# Altium schematic sheet Power.SchDoc(Power)
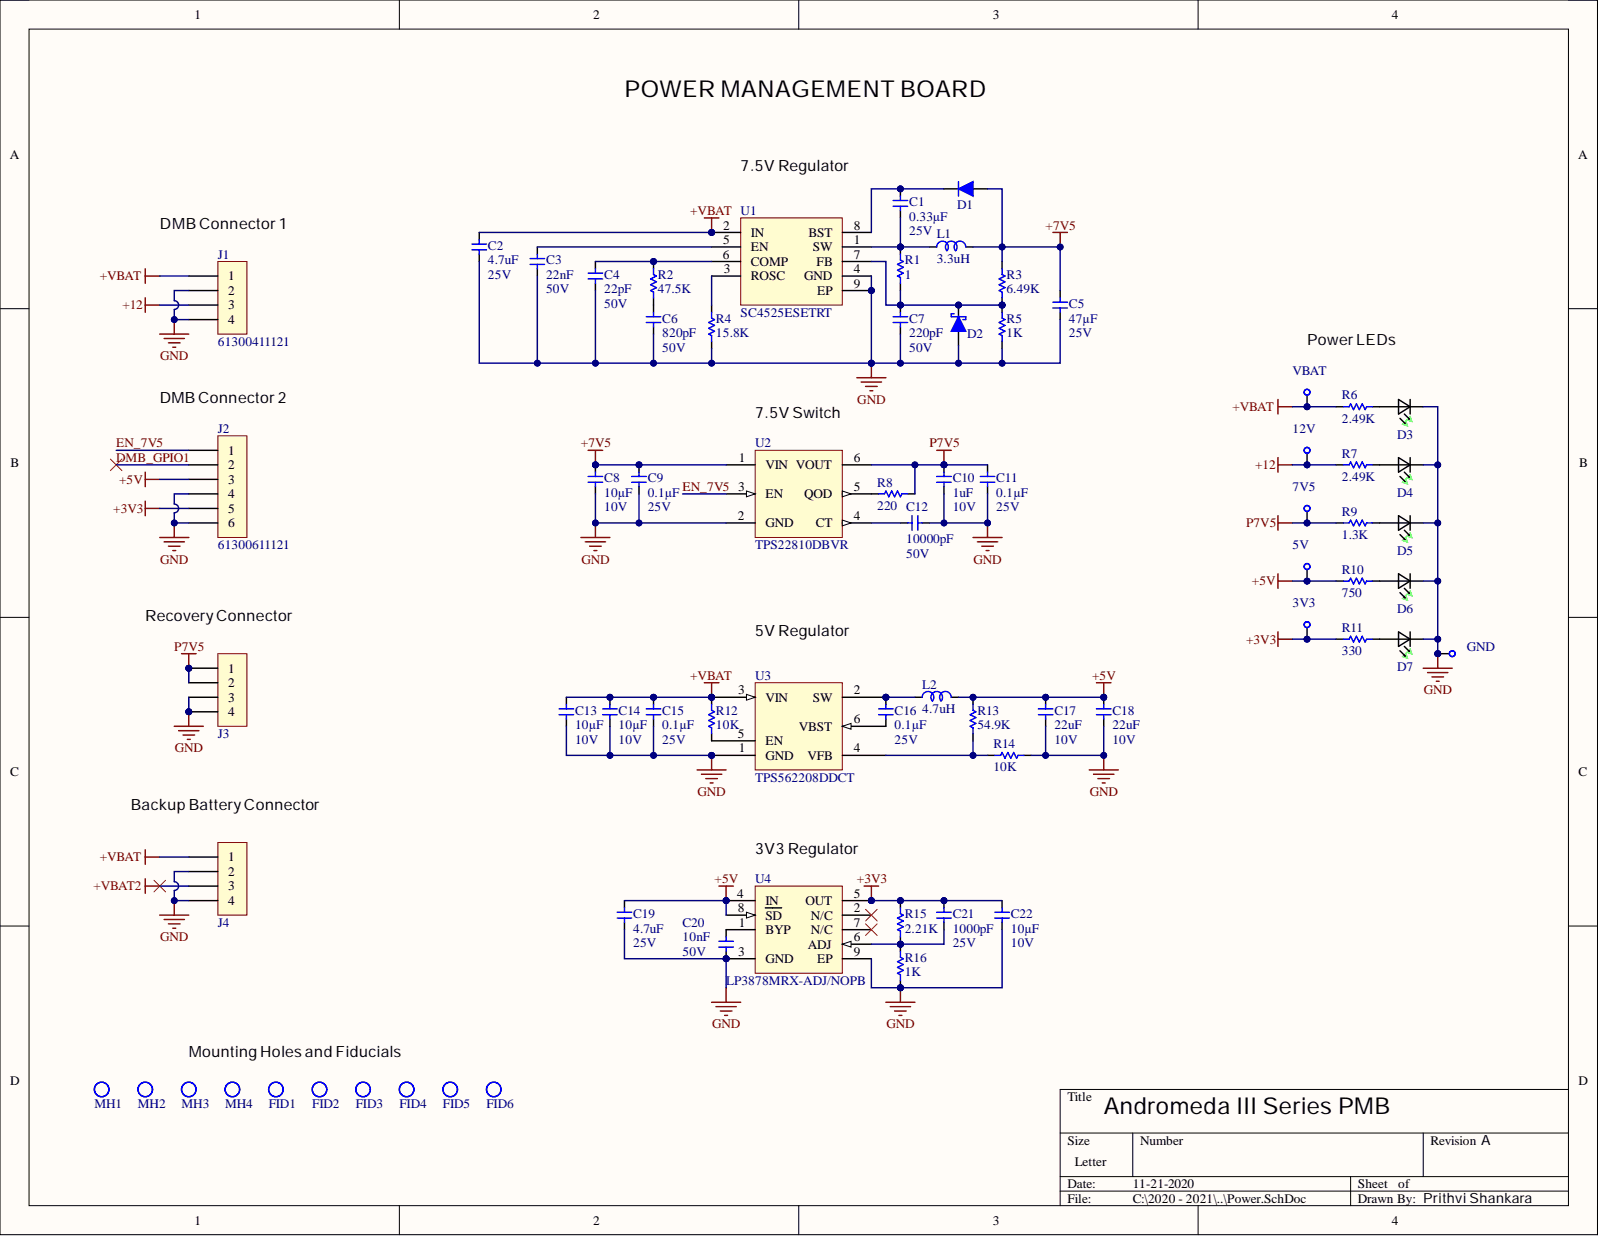
[diagram p0: Power.SchDoc]

## Parts
3V3  Testpoint  [Testpoint]  (value not drawn)  (no BOM)
5V  Testpoint  [Testpoint]  (value not drawn)  (no BOM)
7V5  Testpoint  [Testpoint]  (value not drawn)  (no BOM)
12V  Testpoint  [Testpoint]  (value not drawn)  (no BOM)
C1  Capacitance: 0.33µF  Voltage - Rated: 25V  [0603[1608]]  (hidden comment: CGA3E3X7R1E334K080AB)
C2  Capacitance: 4.7uF  Voltage - Rated: 25V  [0603[1608]]  (hidden comment: CL10A475KA8NQNC)
C3  Capacitance: 22nF  Voltage - Rated: 50V  [0603[1608]]  (hidden comment: CC0603KRX7R9BB223)
C4  Capacitance: 22pF  Voltage - Rated: 50V  [0603[1608]]  (hidden comment: CC0603JRNPO9BN220)
C5  Capacitance: 47µF  Voltage - Rated: 25V  [1206[3216]]  (hidden comment: C3216X5R1E476M160AC)
C6  Capacitance: 820pF  Voltage - Rated: 50V  [0603[1608]]  (hidden comment: CC0603KRX7R9BB821)
C7  Capacitance: 220pF  Voltage - Rated: 50V  [0603[1608]]  (hidden comment: CC0603KRX7R9BB221)
C8  Capacitance: 10µF  Voltage - Rated: 10V  [0603[1608]]  (hidden comment: CL10A106MP8NNNC)
C9  Capacitance: 0.1µF  Voltage - Rated: 25V  [0603[1608]]  (hidden comment: CGA3E2X7R1E104K080AA)
C10  Capacitance: 1uF  Voltage - Rated: 10V  [0603[1608]]  (hidden comment: CL10A105KP8NNNC)
C11  Capacitance: 0.1µF  Voltage - Rated: 25V  [0603[1608]]  (hidden comment: CGA3E2X7R1E104K080AA)
C12  Capacitance: 10000pF  Voltage - Rated: 50V  [0603[1608]]  (hidden comment: CGA3E2X7R1H103K080AA)
C13  Capacitance: 10µF  Voltage - Rated: 10V  [0603[1608]]  (hidden comment: CL10A106MP8NNNC)
C14  Capacitance: 10µF  Voltage - Rated: 10V  [0603[1608]]  (hidden comment: CL10A106MP8NNNC)
C15  Capacitance: 0.1µF  Voltage - Rated: 25V  [0603[1608]]  (hidden comment: CGA3E2X7R1E104K080AA)
C16  Capacitance: 0.1µF  Voltage - Rated: 25V  [0603[1608]]  (hidden comment: CGA3E2X7R1E104K080AA)
C17  Capacitance: 22uF  Voltage - Rated: 10V  [0603[1608]]  (hidden comment: C1608X5R1A226M080AC)
C18  Capacitance: 22uF  Voltage - Rated: 10V  [0603[1608]]  (hidden comment: C1608X5R1A226M080AC)
C19  Capacitance: 4.7uF  Voltage - Rated: 25V  [0603[1608]]  (hidden comment: CL10A475KA8NQNC)
C20  Capacitance: 10nF  Voltage - Rated: 50V  [0603[1608]]  (hidden comment: GRM1885C1H103JA01D)
C21  Capacitance: 1000pF  Voltage - Rated: 25V  [0603[1608]]  (hidden comment: C0603C102K3GACTU)
C22  Capacitance: 10µF  Voltage - Rated: 10V  [0603[1608]]  (hidden comment: CL10A106MP8NNNC)
D1  1N4148W-7-F  [1N4148W-7-F]  (value not drawn)
D2  B330A-13-F  [B330A-13-F]  (value not drawn)
D3  LTST-C191KGKT  [LTST-C191KGKT]  (value not drawn)
D4  LTST-C191KGKT  [LTST-C191KGKT]  (value not drawn)
D5  LTST-C191KGKT  [LTST-C191KGKT]  (value not drawn)
D6  LTST-C191KGKT  [LTST-C191KGKT]  (value not drawn)
D7  LTST-C191KGKT  [LTST-C191KGKT]  (value not drawn)
FID1  FID_1MM_ROUND_SL  [FID_1MM_ROUND_SL]  (value not drawn)  (no BOM)
FID2  FID_1MM_ROUND_SL  [FID_1MM_ROUND_SL]  (value not drawn)  (no BOM)
FID3  FID_1MM_ROUND_SL  [FID_1MM_ROUND_SL]  (value not drawn)  (no BOM)
FID4  FID_1MM_ROUND_SL  [FID_1MM_ROUND_SL]  (value not drawn)  (no BOM)
FID5  FID_1MM_ROUND_SL  [FID_1MM_ROUND_SL]  (value not drawn)  (no BOM)
FID6  FID_1MM_ROUND_SL  [FID_1MM_ROUND_SL]  (value not drawn)  (no BOM)
GND  Testpoint  [Testpoint]  (value not drawn)  (no BOM)
J1  61300411121  [61300411121]
J2  61300611121  [61300611121]
J3  0430450400  [0430450400]  (value not drawn)
J4  0430450400  [0430450400]  (value not drawn)
L1  value 3.3uH  [744777003]  (hidden comment: 744777003)
L2  value 4.7uH  [DFE252012P-4R7M=P2]  (hidden comment: DFE252012P-4R7M=P2)
MH1  9774110360R  [Standoff]  (value not drawn)
MH2  9774110360R  [Standoff]  (value not drawn)
MH3  Mounting Hole  [MOUNT-HOLE]  (no BOM)
MH4  Mounting Hole  [MOUNT-HOLE]  (no BOM)
R1  RC0603FR-071RL  [0603[1608]]  (value not drawn)
R2  Resistance: 47.5K  [0603[1608]]  (hidden comment: ERA-3AEB4752V)
R3  Resistance: 6.49K  [0603[1608]]  (hidden comment: RT0603DRE076K49L)
R4  Resistance: 15.8K  [0603[1608]]  (hidden comment: ERA-3AEB1582V)
R5  Resistance: 1K  [0603[1608]]  (hidden comment: RC0603FR-071KL)
R6  Resistance: 2.49K  [0603[1608]]  (hidden comment: ERA-3AEB2491V)
R7  Resistance: 2.49K  [0603[1608]]  (hidden comment: ERA-3AEB2491V)
R8  CRGCQ0603F220R  [0603[1608]]  (value not drawn)
R9  Resistance: 1.3K  [0603[1608]]  (hidden comment: MCT06030C1301FP500)
R10  ESR03EZPJ751  [0603[1608]]  (value not drawn)
R11  RC1608F331CS  [0603[1608]]  (value not drawn)
R12  Resistance: 10K  [0603[1608]]  (hidden comment: ERJ-3GEYJ103V)
R13  Resistance: 54.9K  [0603[1608]]  (hidden comment: RC0603FR-0754K9L)
R14  Resistance: 10K  [0603[1608]]  (hidden comment: ERJ-3GEYJ103V)
R15  Resistance: 2.21K  [0603[1608]]  (hidden comment: ERJ-3EKF2211V)
R16  Resistance: 1K  [0603[1608]]  (hidden comment: RC0603FR-071KL)
U1  SC4525ESETRT  [SOIC127P600X175_HS-9N]
U2  TPS22810DBVR  [SOT95P280X145-6N]
U3  TPS562208DDCT  [TPS562208DDCT]
U4  LP3878MRX-ADJ/NOPB  [SOIC127P600X170_HS-9N]
VBAT  Testpoint  [Testpoint]  (value not drawn)  (no BOM)

## Nets
+3V3: 3V3.1 C21.2 C22.2 J2.5 R11.1 R15.2 U4.5(OUT)
+5V: 5V.1 C17.2 C18.2 C19.2 J2.3 L2.2 R10.1 R13.1 U4.4(IN) U4.8(SD)
+7V5: C5.2 C8.2 C9.2 D1.1 L1.2 R3.2 U2.1(VIN)
+12: 12V.1 J1.3 R7.1
+VBAT: C2.2 C13.2 C14.2 C15.2 J1.1 J4.1 R6.1 R12.2 U1.2(IN) U3.3(VIN) VBAT.1
+VBAT2: J4.3
GND: C2.1 C3.1 C4.1 C5.1 C6.1 C7.1 C8.1 C9.1 C10.1 C11.1 C12.2 C13.1 C14.1 C15.1 C17.1 C18.1 C19.1 C20.1 C22.1 D2.1 D3.1 D4.1 D5.1 D6.1 D7.1 GND.1 J1.2 J1.4 J2.4 J2.6 J3.3 J3.4 J4.2 J4.4 R4.1 R5.1 R14.1 R16.1 U1.4(GND) U1.9(EP) U2.2(GND) U3.1(GND) U4.3(GND) U4.9(EP)
P7V5: 7V5.1 C10.2 C11.2 J3.1 J3.2 R8.2 R9.1 U2.6(VOUT)
DMB_GPIO1: J2.2
EN_7V5: J2.1 U2.3(EN)
NetC1_1: C1.1 L1.1 R1.2 U1.1(SW)
NetC1_2: C1.2 D1.2 U1.8(BST)
NetC3_2: C3.2 U1.5(EN)
NetC4_2: C4.2 R2.2 U1.6(COMP)
NetC6_2: C6.2 R2.1
NetC7_2: C7.2 D2.2 R1.1 R3.1 R5.2 U1.7(FB)
NetC12_1: C12.1 U2.4(CT)
NetC16_1: C16.1 U3.6(VBST)
NetC16_2: C16.2 L2.1 U3.2(SW)
NetC20_2: C20.2 U4.1(BYP)
NetC21_1: C21.1 R15.1 R16.2 U4.6(ADJ)
NetD3_2: D3.2 R6.2
NetD4_2: D4.2 R7.2
NetD5_2: D5.2 R9.2
NetD6_2: D6.2 R10.2
NetD7_2: D7.2 R11.2
NetR4_2: R4.2 U1.3(ROSC)
NetR8_1: R8.1 U2.5(QOD)
NetR12_1: R12.1 U3.5(EN)
NetR13_2: R13.2 R14.2 U3.4(VFB)

Not connected: U4 2(N/C) 7(N/C)

## Library data of the parts
3V3 5V 7V5 12V GND VBAT = Testpoint: TESTPOINT 0.7MMD Hole, 1.2MMW, 1.2MML; Library Name: SOARSchematics.SchLib
C1 = CGA3E3X7R1E334K080AB: CAP CER 0.33UF 25V X7R 0603; Manufacturer: TDK Corporation; Tolerance: ±10%; Component Kind: Standard; ComponentLink1Description: Datasheet; ComponentLink1URL: https://content.kemet.com/datasheets/KEM_C1003_C0G_SMD.pdf; Manufacturer Part Number: CGA3E3X7R1E334K080AB; Mounting Type: Surface Mount, MLCC; Operating Temperature: -55°C ~ 125°C; Package / Case: 0603 (1608 Metric); Size / Dimension: 0.063" L x 0.031" W (1.60mm x 0.80mm); Supplier 1: Digi-Key; Supplier Part Number 1: 445-12544-1-ND; Temperature Coefficient: X7R; Thickness (Max): 0.034" (0.87mm); Library Name: SOARSchematics.SchLib
C2 C19 = CL10A475KA8NQNC: CAP CER 4.7UF 25V X5R 0603; Manufacturer: Samsung Electro-Mechanics; Tolerance: ±10%; Component Kind: Standard; ComponentLink1Description: Datasheet; ComponentLink1URL: http://www.samsungsem.com/kr/support/product-search/mlcc/__icsFiles/afieldfile/2019/05/10/19-CL10A475KA8NQNC.pdf; Manufacturer Part Number: CL10A475KA8NQNC; Mounting Type: Surface Mount, MLCC; Operating Temperature: -55°C ~ 85°C; Package / Case: 0603 (1608 Metric); Size / Dimension: 0.063" L x 0.031" W (1.60mm x 0.80mm); Supplier 1: Digi-Key; Supplier Part Number 1: 1276-1900-1-ND; Temperature Coefficient: X5R; Thickness (Max): 0.035" (0.90mm); Library Name: SOARSchematics.SchLib
C3 = CC0603KRX7R9BB223: CAP CER 0.022UF 50V X7R 0603; Manufacturer: Yageo; Tolerance: ±10%; Component Kind: Standard; ComponentLink1Description: Datasheet; ComponentLink1URL: https://www.yageo.com/upload/media/product/productsearch/datasheet/mlcc/UPY-GP_NP0_16V-to-50V_18.pdf; Manufacturer Part Number: CC0603KRX7R9BB223; Mounting Type: Surface Mount, MLCC; Operating Temperature: -55°C ~ 125°C; Package / Case: 0603 (1608 Metric); Size / Dimension: 0.063" L x 0.031" W (1.60mm x 0.80mm); Supplier 1: Digi-Key; Supplier Part Number 1: 311-1370-1-ND; Temperature Coefficient: X7R; Thickness (Max): 0.035" (0.90mm); Library Name: SOARSchematics.SchLib
C4 = CC0603JRNPO9BN220: CAP CER 22PF 50V C0G/NPO 0603; Manufacturer: Yageo; Temperature Coefficient: C0G, NP0; Tolerance: ±5%; Component Kind: Standard; ComponentLink1Description: Datasheet; ComponentLink1URL: https://www.yageo.com/upload/media/product/productsearch/datasheet/mlcc/UPY-GP_NP0_16V-to-50V_18.pdf; Manufacturer Part Number: CC0603JRNPO9BN220; Mounting Type: Surface Mount, MLCC; Operating Temperature: -55°C ~ 125°C; Package / Case: 0603 (1608 Metric); Size / Dimension: 0.063" L x 0.031" W (1.60mm x 0.80mm); Supplier 1: Digi-Key; Supplier Part Number 1: 311-1062-1-ND; Thickness (Max): 0.035" (0.90mm); Library Name: SOARSchematics.SchLib
C5 = C3216X5R1E476M160AC: CAP CER 47UF 25V X5R 1206; Manufacturer: TDK Corporation; Tolerance: ±20%; Component Kind: Standard; ComponentLink1Description: Datasheet; ComponentLink1URL: http://www.samsungsem.com/kr/support/product-search/mlcc/__icsFiles/afieldfile/2019/05/10/19-CL10A105KP8NNNC.pdf; Manufacturer Part Number: C3216X5R1E476M160AC; Mounting Type: Surface Mount, MLCC; Operating Temperature: -55°C ~ 85°C; Package / Case: 1206 (3216 Metric); Size / Dimension: 0.126" L x 0.063" W (3.20mm x 1.60mm); Supplier 1: Digi-Key; Supplier Part Number 1: 445-8047-1-ND; Temperature Coefficient: X5R; Thickness (Max): 0.071" (1.80mm); Library Name: SOARSchematics.SchLib
C6 = CC0603KRX7R9BB821: CAP CER 820PF 50V X7R 0603; Manufacturer: Yageo; Tolerance: ±10%; Component Kind: Standard; ComponentLink1Description: Datasheet; ComponentLink1URL: https://www.yageo.com/upload/media/product/productsearch/datasheet/mlcc/UPY-GP_NP0_16V-to-50V_18.pdf; Manufacturer Part Number: CC0603KRX7R9BB821; Mounting Type: Surface Mount, MLCC; Operating Temperature: -55°C ~ 125°C; Package / Case: 0603 (1608 Metric); Size / Dimension: 0.063" L x 0.031" W (1.60mm x 0.80mm); Supplier 1: Digi-Key; Supplier Part Number 1: 311-1189-1-ND; Temperature Coefficient: X7R; Thickness (Max): 0.035" (0.90mm); Library Name: SOARSchematics.SchLib
C7 = CC0603KRX7R9BB221: CAP CER 220PF 50V X7R 0603; Manufacturer: Yageo; Tolerance: ±10%; Component Kind: Standard; ComponentLink1Description: Datasheet; ComponentLink1URL: https://www.yageo.com/upload/media/product/productsearch/datasheet/mlcc/UPY-GP_NP0_16V-to-50V_18.pdf; Manufacturer Part Number: CC0603KRX7R9BB221; Mounting Type: Surface Mount, MLCC; Operating Temperature: -55°C ~ 125°C; Package / Case: 0603 (1608 Metric); Size / Dimension: 0.063" L x 0.031" W (1.60mm x 0.80mm); Supplier 1: Digi-Key; Supplier Part Number 1: 311-1077-1-ND; Temperature Coefficient: X7R; Thickness (Max): 0.035" (0.90mm); Library Name: SOARSchematics.SchLib
C8 C13 C14 C22 = CL10A106MP8NNNC: CAP CER 10UF 10V X5R 0603; Manufacturer: Samsung Electro-Mechanics; Tolerance: ±20%; Component Kind: Standard; ComponentLink1Description: Datasheet; ComponentLink1URL: https://static6.arrow.com/aropdfconversion/f91a1722e2705c3d73cfb1979021b64ae4055093/19-cl10a106mp8nnnc.pdf; Manufacturer Part Number: CL10A106MP8NNNC; Mounting Type: Surface Mount, MLCC; Operating Temperature: -55°C ~ 85°C; Package / Case: 0603 (1608 Metric); Size / Dimension: 0.063" L x 0.031" W (1.60mm x 0.80mm); Supplier 1: Digi-Key; Supplier Part Number 1: 1276-1871-1-ND; Temperature Coefficient: X5R; Thickness (Max): 0.035" (0.90mm); Library Name: SOARSchematics.SchLib
C9 C11 C15 C16 = CGA3E2X7R1E104K080AA: CAP CER 0.1UF 25V X7R 0603; Manufacturer: TDK Corporation; Tolerance: ±10%; Component Kind: Standard; ComponentLink1Description: Datasheet; ComponentLink1URL: https://product.tdk.com/info/en/catalog/spec/mlccspec_automotive_general_en.pdf; Manufacturer Part Number: CGA3E2X7R1E104K080AA; Mounting Type: Surface Mount, MLCC; Operating Temperature: -55°C ~ 125°C; Package / Case: 0603 (1608 Metric); Size / Dimension: 0.063" L x 0.031" W (1.60mm x 0.80mm); Supplier 1: Digi-Key; Supplier Part Number 1: 445-5667-1-ND; Temperature Coefficient: X7R; Thickness (Max): 0.035" (0.90mm); Library Name: SOARSchematics.SchLib
C10 = CL10A105KP8NNNC: CAP CER 1UF 10V X5R 0603; Manufacturer: Samsung Electro-Mechanics; Tolerance: ±10%; Component Kind: Standard; ComponentLink1Description: Datasheet; ComponentLink1URL: http://www.samsungsem.com/kr/support/product-search/mlcc/__icsFiles/afieldfile/2019/05/10/19-CL10A105KP8NNNC.pdf; Manufacturer Part Number: CL10A105KP8NNNC; Mounting Type: Surface Mount, MLCC; Operating Temperature: -55°C ~ 85°C; Package / Case: 0603 (1608 Metric); Size / Dimension: 0.063" L x 0.031" W (1.60mm x 0.80mm); Supplier 1: Digi-Key; Supplier Part Number 1: 1276-1182-1-ND; Temperature Coefficient: X5R; Thickness (Max): 0.035" (0.90mm); Library Name: SOARSchematics.SchLib
C12 = CGA3E2X7R1H103K080AA: CAP CER 10000PF 50V X7R 0603; Manufacturer: TDK Corporation; Tolerance: ±10%; Component Kind: Standard; ComponentLink1Description: Datasheet; ComponentLink1URL: https://product.tdk.com/info/en/catalog/spec/mlccspec_automotive_general_en.pdf; Manufacturer Part Number: CGA3E2X7R1H103K080AA; Mounting Type: Surface Mount, MLCC; Operating Temperature: -55°C ~ 125°C; Package / Case: 0603 (1608 Metric); Size / Dimension: 0.063" L x 0.031" W (1.60mm x 0.80mm); Supplier 1: Digi-Key; Supplier Part Number 1: 445-5662-1-ND; Temperature Coefficient: X7R; Thickness (Max): 0.035" (0.90mm); Library Name: SOARSchematics.SchLib
C17 C18 = C1608X5R1A226M080AC: CAP CER 22UF 10V X5R 0603; Manufacturer: TDK Corporation; Tolerance: ±20%; Component Kind: Standard; ComponentLink1Description: Datasheet; ComponentLink1URL: http://datasheets.avx.com/C0GNP0-Dielectric.pdf; Manufacturer Part Number: C1608X5R1A226M080AC; Mounting Type: Surface Mount, MLCC; Operating Temperature: -55°C ~ 85°C; Package / Case: 0603 (1608 Metric); Size / Dimension: 0.063" L x 0.031" W (1.60mm x 0.80mm); Supplier 1: Digi-Key; Supplier Part Number 1: 445-9077-1-ND; Temperature Coefficient: X5R; Thickness (Max): 0.039" (1.00mm); Library Name: SOARSchematics.SchLib
C20 = GRM1885C1H103JA01D: CAP CER 10000PF 50V C0G/NP0 0603; Manufacturer: Murata Electronics; Temperature Coefficient: C0G, NP0; Tolerance: ±50%; Component Kind: Standard; ComponentLink1Description: Datasheet; ComponentLink1URL: https://www.murata.com/~/media/webrenewal/support/library/catalog/products/capacitor/mlcc/c02e.ashx?la=en-us; Manufacturer Part Number: GRM1885C1H103JA01D; Mounting Type: Surface Mount, MLCC; Operating Temperature: -55°C ~ 125°C; Package / Case: 0603 (1608 Metric); Size / Dimension: 0.063" L x 0.031" W (1.60mm x 0.80mm); Supplier 1: Digi-Key; Supplier Part Number 1: 490-9666-1-ND; Thickness (Max): 0.035" (0.90mm); Library Name: SOARSchematics.SchLib
C21 = C0603C102K3GACTU: CAP CER 1000PF 25V C0G/NP0 0603; Manufacturer: KEMET; Temperature Coefficient: C0G, NP0; Tolerance: ±10%; Component Kind: Standard; ComponentLink1Description: Datasheet; ComponentLink1URL: https://content.kemet.com/datasheets/KEM_C1003_C0G_SMD.pdf; Manufacturer Part Number: C0603C102K3GACTU; Mounting Type: Surface Mount, MLCC; Operating Temperature: -55°C ~ 85°C; Package / Case: 0603 (1608 Metric); Size / Dimension: 0.063" L x 0.031" W (1.60mm x 0.80mm); Supplier 1: Digi-Key; Supplier Part Number 1: 399-7833-1-ND; Thickness (Max): 0.034" (0.87mm); Library Name: SOARSchematics.SchLib
D1 = 1N4148W-7-F: DIODE GEN PURP 100V 300MA SOD123; Manufacturer: Diodes Incorporated; Capacitance @ Vr, F: 2pF @ 0V, 1MHz; Current - Average Rectified (Io): 300mA (DC); Current - Reverse Leakage @ Vr: 1µA @ 75V; Diode Type: Standard; Reverse Recovery Time (trr): 4ns; Speed: Small Signal =< 200mA (Io), Any Speed; Voltage - Forward (Vf) (Max) @ If: 1.25V @ 150mA; Component Kind: Standard; ComponentLink1Description: Datasheet; ComponentLink1URL: https://www.diodes.com/assets/Datasheets/ds30086.pdf; Manufacturer Part Number: 1N4148W-7-F; Mounting Type: Surface Mount; Operating Temperature - Junction: -65°C ~ 150°C; Package / Case: SOD-123; Supplier 1: Digi-Key; Supplier Part Number 1: 1N4148W-FDICT-ND; Voltage - DC Reverse (Vr) (Max): 100V; Library Name: SOARSchematics.SchLib
D2 = B330A-13-F: DIODE SCHOTTKY 30V 3A SMA; Manufacturer: Diodes Incorporated; 500mV @ 3A: Fast Recovery =< 500ns, > 200mA (Io); Capacitance @ Vr, F: 200pF @ 4V, 1MHz; Current - Reverse Leakage @ Vr: 500µA @ 30V; Voltage - Forward (Vf) (Max) @ If: 500mV @ 3A; Component Kind: Standard; ComponentLink1Description: Datasheet; ComponentLink1URL: https://www.diodes.com/assets/Datasheets/ds30891.pdf; Current - Average Rectified (Io): 3A; Manufacturer Part Number: B330A-13-F; Mounting Type: Surface Mount; Operating Temperature - Junction: -55°C ~ 150°C; Package / Case: DO-214AC, SMA; Supplier 1: Digi-Key; Supplier Part Number 1: B330A-FDICT-ND; Type: Schottky; Voltage - DC Reverse (Vr) (Max): 30V; Library Name: SOARSchematics.SchLib
D3-D7 = LTST-C191KGKT: LED GREEN CLEAR SMD 0603; Manufacturer: Lite-On Inc.; Current - Test: 20mA; Lens Color: Colourless; Lens Size: 1.10mm x 0.80mm; Lens Style: Rectangle with Flat Top; Millicandela Rating: 35mcd; Viewing Angle: 130°; Voltage - Forward (Vf) (Typ): 2V; Wavelength - Dominant: 571nm; Wavelength - Peak: 574nm; Colour: Green; Component Kind: Standard; ComponentLink1Description: Datasheet; ComponentLink1URL: http://optoelectronics.liteon.com/upload/download/DS22-2000-228/LTST-C191KGKT.PDF; Height (Max): 0.65mm; Lens Transparency: Clear; Manufacturer Part Number: LTST-C191KGKT; Mounting Type: Surface Mount; Package / Case: 0603 (1608 Metric); Size / Dimension: 1.60mm L x 0.80mm W; Supplier 1: Digi-Key; Supplier Part Number 1: 160-1446-1-ND; Library Name: SOARSchematics.SchLib
FID1-FID6 = FID_1MM_ROUND_SL: Fiducial 1mm Round Single Layer; Library Name: SOARSchematics.SchLib
J1 = 61300411121: CONN HEADER VERT 4POS MALE 2.54MM; Manufacturer: Würth Elektronik; Contact Length - Mating: 0.236" (6.00mm); Contact Length - Post: 0.118" (3.00mm); Current Rating (Amps): 3A; Material Flammability Rating: UL94 V-0; Number of Positions: 4; Overall Contact Length: 0.454" (11.54mm); Pitch - Mating: 0.100" (2.54mm); Shrouding: Unshrouded; Style: Board to Board or Cable; Voltage Rating: 250V; Component Kind: Standard; ComponentLink1Description: Drawing; ComponentLink1URL: https://www.we-online.de/katalog/datasheet/6130xx11121.pdf; Connector Type: Header; Contact Finish - Mating: Gold; Contact Material: Copper Alloy; Contact Shape: Square; Contact Type: Male Pin; Fastening Type: Push-Pull; Insulation Color: Black; Insulation Height: 0.100" (2.54mm); Insulation Material: Polybutylene Terephthalate (PBT); Manufacturer Part Number: 61300411121; Mounting Type: Through Hole; Number of Rows: 1; Operating Temperature: -40°C ~ 105°C; Supplier 1: Digi-Key; Supplier Part Number 1: 732-5317-ND; Termination: Solder; Library Name: SOARSchematics.SchLib
J2 = 61300611121: CONN HEADER VERT 6POS MALE 2.54MM; Manufacturer: Würth Elektronik; Contact Length - Mating: 0.236" (6.00mm); Contact Length - Post: 0.118" (3.00mm); Current Rating (Amps): 3A; Material Flammability Rating: UL94 V-0; Number of Positions: 6; Overall Contact Length: 0.454" (11.54mm); Pitch - Mating: 0.100" (2.54mm); Shrouding: Unshrouded; Style: Board to Board or Cable; Voltage Rating: 250V; Component Kind: Standard; ComponentLink1Description: Drawing; ComponentLink1URL: https://www.we-online.de/katalog/datasheet/6130xx11121.pdf; Connector Type: Header; Contact Finish - Mating: Gold; Contact Material: Copper Alloy; Contact Shape: Square; Contact Type: Male Pin; Fastening Type: Push-Pull; Insulation Color: Black; Insulation Height: 0.100" (2.54mm); Insulation Material: Polybutylene Terephthalate (PBT); Manufacturer Part Number: 61300611121; Mounting Type: Through Hole; Number of Rows: 1; Operating Temperature: -40°C ~ 105°C; Supplier 1: Digi-Key; Supplier Part Number 1: 732-5319-ND; Termination: Solder; Library Name: SOARSchematics.SchLib
J3 J4 = 0430450400: CONN HEADER R/A 4POS 3MM; Manufacturer: Molex; Contact Length - Post: 0.125" (3.18mm); Current Rating (Amps): 8A; Material Flammability Rating: UL94 V-0; Number of Positions: 4; Row Spacing - Mating: 0.118" (3.00mm); Shrouding: Shrouded - 4 Wall; Voltage Rating: 600V; Component Kind: Standard; ComponentLink1Description: Datasheet; ComponentLink1URL: https://www.molex.com/pdm_docs/sd/430450400_sd.pdf; ComponentLink2Description: Product Specification; ComponentLink2URL: https://www.molex.com/pdm_docs/ps/PS-43045.pdf; Contact Finish - Mating: Tin; Contact Finish - Post: Tin; Contact Finish Thickness - Mating: 100.0µin (2.54µm); Contact Material: Brass; Contact Shape: Square; Contact Type: 0.118" (3.00mm); Fastening Type: Locking Ramp; Features: Board Lock; Insulation Color: Black; Insulation Height: 0.290" (7.37mm); Insulation Material: Liquid Crystal Polymer (LCP), Glass Filled; Manufacturer Part Number: 0430450400; Mounting Type: Through Hole, Right Angle; Number of Rows: 2; Supplier 1: Digi-Key; Supplier Part Number 1: WM1814-ND; Termination: Solder; Library Name: SOARSchematics.SchLib
L1 = 744777003: SMT SHIELDED POWER INDUCTOR SIZE; Manufacturer: Würth Elektronik; Current - Saturation: 4.6A; Current Rating (Amps): 3.5A; DC Resistance (DCR): 30mOhm Max; Inductance Frequency - Test: 55MHz; Material - Core: Ferrite; Tolerance: +-20%; Type: Wirewound; Component Kind: Standard; ComponentLink1Description: Datasheet; ComponentLink1URL: https://www.we-online.com/catalog/datasheet/744777003.pdf; Height - Seated (Max): 0.177"" (4.50mm); Mounting Type: Surface Mount; Operating Temperature: -40°C ~ 125°C; Ratings: AEC-Q200; Series: 744777003; Shielding: Shielded; Size/Dimension: 0.287"" L x 0.287"" W (7.30mm x 7.30mm); Supplier 1: Digi-Key; Supplier Device Package: 7345; Supplier Part Number 1: 732-13497-1-ND; Library Name: SOARSchematics.SchLib
L2 = DFE252012P-4R7M=P2: FIXED IND 4.7UH 2A 200 MOHM SMD; Manufacturer: Murata Electronics; DC Resistance (DCR): 200mOhm Max; Inductance Frequency - Test: 1MHz; Material - Core: Iron Powder; Shielding: Shielded; Tolerance: +-20%; Type: Wirewound; Component Kind: Standard; Current Rating (Amps): 2A; Height - Seated (Max): 0.047" (1.20mm); Manufacturer Part Number: DFE252012P-4R7M=P2; Mounting Type: Surface Mount; Operating Temperature: -40C to 125C; Series: DFE252012P; Size/Dimension: 0.098" L x 0.079" W (2.50mm x 2.00mm); Supplier 1: Digi-Key; Supplier Part Number 1: 490-10651-1-ND; Library Name: SOARSchematics.SchLib
MH1 MH2 = 9774110360R: ROUND STANDOFF M3X0.5 STEEL 11MM; Manufacturer: Würth Elektronik; Between Board Height: 0.433" (11.00mm); Gender: Female; Threaded/Unthreaded: Threaded; Component Kind: Standard; ComponentLink1Description: Datasheet; ComponentLink1URL: https://www.keyelco.com/userAssets/file/M65p77.pdf; Diameter - Outside: 0.236" (5.99mm); Features: Surface Mount; Length - Overall: 0.488" (12.40mm); Manufacturer Part Number: 9774110360R; Material: Steel; Screw, Thread Size: M3x0.5; Supplier 1: Digi-Key; Supplier Part Number 1: 732-5278-1-ND; Type: Round Standoff; Library Name: SOARSchematics.SchLib
MH3 MH4 = Mounting Hole: #4 Screw, 0.116in Diameter; Library Name: SOARSchematics.SchLib
R1 = RC0603FR-071RL: RES SMD 1 OHM 1% 1/10W 0603; Manufacturer: Yageo; Power  (W): 0.1W; Resistance: 1; Temperature Coefficient: ±200ppm/°C; Component Kind: Standard; ComponentLink1Description: Datasheet; ComponentLink1URL: https://www.yageo.com/upload/media/product/productsearch/datasheet/rchip/PYu-RC_Group_51_RoHS_L_10.pdf; Composition: Thick Film; Manufacturer Part Number: RC0603FR-071RL; Operating Temperature: -55°C ~ 155°C; Package: 0603 (1608 Metric); Supplier 1: Digi-Key; Supplier Part Number 1: 311-1.00HRCT-ND; Tolerance: ±1%; Library Name: SOARSchematics.SchLib
R2 = ERA-3AEB4752V: RES SMD 47.5KOHM 0.1% 1/10W 0603; Manufacturer: Panasonic Electronic Components; Power  (W): 0.1W; Temperature Coefficient: ±25ppm/°C; Component Kind: Standard; ComponentLink1Description: Datasheet; ComponentLink1URL: https://industrial.panasonic.com/cdbs/www-data/pdf/RDM0000/AOA0000C307.pdf; Composition: Thin Film; Manufacturer Part Number: ERA-3AEB4752V; Operating Temperature: -55°C ~ 155°C; Package: 0603 (1608 Metric); Supplier 1: Digi-Key; Supplier Part Number 1: P47.5KDBCT-ND; Tolerance: ±0.1%; Library Name: SOARSchematics.SchLib
R3 = RT0603DRE076K49L: RES SMD 6.49KOHM 0.5% 1/10W 0603; Manufacturer: Yageo; Power  (W): 0.1W; Temperature Coefficient: ±500ppm/°C; Component Kind: Standard; ComponentLink1Description: Datasheet; ComponentLink1URL: https://4donline.ihs.com/images/VipMasterIC/IC/YAGO/YAGO-S-A0008510989/YAGO-S-A0008510989-1.pdf?hkey=52A5661711E402568146F3353EA87419; Composition: Thick Film; Manufacturer Part Number: RT0603DRE076K49L; Operating Temperature: -55°C ~ 155°C; Package: 0603 (1608 Metric); Supplier 1: Digi-Key; Supplier Part Number 1: 311-2658-1-ND; Tolerance: ±0.5%; Library Name: SOARSchematics.SchLib
R4 = ERA-3AEB1582V: RES SMD 15.8KOHM 0.1% 1/10W 0603; Manufacturer: Panasonic Electronic Components; Power  (W): 0.1W; Temperature Coefficient: ±25ppm/°C; Component Kind: Standard; ComponentLink1Description: Datasheet; ComponentLink1URL: https://industrial.panasonic.com/cdbs/www-data/pdf/RDM0000/AOA0000C307.pdf; Composition: Thin Film; Manufacturer Part Number: ERA-3AEB1582V; Operating Temperature: -55°C ~ 155°C; Package: 0603 (1608 Metric); Supplier 1: Digi-Key; Supplier Part Number 1: P15.8KDBCT-ND; Tolerance: ±0.1%; Library Name: SOARSchematics.SchLib
R5 R16 = RC0603FR-071KL: RES SMD 1K OHM 1% 1/10W 0603; Manufacturer: Yageo; Power  (W): 0.1W; Temperature Coefficient: ±100ppm/°C; Component Kind: Standard; ComponentLink1Description: Datasheet; ComponentLink1URL: https://www.yageo.com/upload/media/product/productsearch/datasheet/rchip/PYu-RC_Group_51_RoHS_L_10.pdf; Composition: Thick Film; Manufacturer Part Number: RC0603FR-071KL; Operating Temperature: -55°C ~ 155°C; Package: 0603 (1608 Metric); Supplier 1: Digi-Key; Supplier Part Number 1: 311-30.0KHRCT-ND; Tolerance: ±1%; Library Name: SOARSchematics.SchLib
R6 R7 = ERA-3AEB2491V: RES SMD 2.49KOHM 0.1% 1/10W 0603; Manufacturer: Panasonic Electronic Components; Power  (W): 0.1W; Temperature Coefficient: ±25ppm/°C; Component Kind: Standard; ComponentLink1Description: Datasheet; ComponentLink1URL: https://industrial.panasonic.com/cdbs/www-data/pdf/RDM0000/AOA0000C307.pdf; Composition: Thin Film; Manufacturer Part Number: ERA-3AEB2491V; Operating Temperature: -55°C ~ 155°C; Package: 0603 (1608 Metric); Supplier 1: Digi-Key; Supplier Part Number 1: P2.49KDBCT-ND; Tolerance: ±0.1%; Library Name: SOARSchematics.SchLib
R8 = CRGCQ0603F220R: CRGCQ 0603 220R 1%; Manufacturer: TE Connectivity Passive Product; Power  (W): 0.1W; Resistance: 220; Temperature Coefficient: ±100ppm/°C; Component Kind: Standard; ComponentLink1Description: Datasheet; ComponentLink1URL: https://www.yageo.com/upload/media/product/productsearch/datasheet/rchip/PYu-RC_Group_51_RoHS_L_10.pdf; Composition: Thick Film; Manufacturer Part Number: CRGCQ0603F220R; Operating Temperature: -55°C ~ 155°C; Package: 0603 (1608 Metric); Supplier 1: Digi-Key; Supplier Part Number 1: A129680CT-ND; Tolerance: ±1%; Library Name: SOARSchematics.SchLib
R9 = MCT06030C1301FP500: RES SMD 1.3K OHM 1% 1/8W 0603; Manufacturer: Vishay Beyschlag; Temperature Coefficient: ±50ppm/°C; Component Kind: Standard; ComponentLink1Description: Datasheet; ComponentLink1URL: http://www.vishay.com/docs/28705/mcx0x0xpro.pdf; Composition: Thin Film; Manufacturer Part Number: MCT06030C1301FP500; Operating Temperature: -55°C ~ 155°C; Package: 0603 (1608 Metric); Power  (W): 1/8W; Supplier 1: Digi-Key; Supplier Part Number 1: 749-1605-1-ND; Tolerance: ±1%; Library Name: SOARSchematics.SchLib
R10 = ESR03EZPJ751: RES SMD 750 OHM 5% 1/4W 0603; Manufacturer: Rohm Semiconductor; Power  (W): 0.25W; Resistance: 750; Temperature Coefficient: ±200ppm/°C; Component Kind: Standard; ComponentLink1Description: Datasheet; ComponentLink1URL: https://d1d2qsbl8m0m72.cloudfront.net/en/products/databook/datasheet/passive/resistor/chip_resistor/esr-e.pdf; Composition: Thick Film; Manufacturer Part Number: ESR03EZPJ751; Operating Temperature: -55°C ~ 155°C; Package: 0603 (1608 Metric); Supplier 1: Digi-Key; Supplier Part Number 1: RHM750DCT-ND; Tolerance: ±5%; Library Name: SOARSchematics.SchLib
R11 = RC1608F331CS: RES SMD 330 OHM 1% 1/10W 0603; Manufacturer: Samsung Electro-Mechanics; Power  (W): 0.1W; Resistance: 330; Temperature Coefficient: ±100ppm/°C; Component Kind: Standard; ComponentLink1Description: Datasheet; ComponentLink1URL: http://www.samsungsem.com/kr/support/product-search/chip-resistor/__icsFiles/afieldfile/2019/01/21/RC_Spec_Sheet(1901).pdf; Composition: Thick Film; Manufacturer Part Number: RC1608F331CS; Operating Temperature: -55°C ~ 155°C; Package: 0603 (1608 Metric); Supplier 1: Digi-Key; Supplier Part Number 1: 1276-4604-2-ND; Tolerance: ±1%; Library Name: SOARSchematics.SchLib
R12 R14 = ERJ-3GEYJ103V: RES SMD 10K OHM 5% 1/10W 0603; Manufacturer: Panasonic Electronic Components; Power  (W): 0.1W; Temperature Coefficient: ±200ppm/°C; Component Kind: Standard; ComponentLink1Description: Datasheet; ComponentLink1URL: http://www.yageo.com/documents/recent/PYu-RC_Group_51_RoHS_L_9.pdf; Composition: Thick Film; Manufacturer Part Number: ERJ-3GEYJ103V; Operating Temperature: -55°C ~ 155°C; Package: 0603 (1608 Metric); Supplier 1: Digi-Key; Supplier Part Number 1: P10KGCT-ND; Tolerance: ±5%; Library Name: SOARSchematics.SchLib
R13 = RC0603FR-0754K9L: RES SMD 54.9K OHM 1% 1/10W 0603; Manufacturer: Yageo; Power  (W): 0.1W; Temperature Coefficient: ±100ppm/°C; Component Kind: Standard; ComponentLink1Description: Datasheet; ComponentLink1URL: https://www.yageo.com/upload/media/product/productsearch/datasheet/rchip/PYu-RC_Group_51_RoHS_L_10.pdf; Composition: Thick Film; Manufacturer Part Number: RC0603FR-0754K9L; Operating Temperature: -55°C ~ 155°C; Package: 0603 (1608 Metric); Supplier 1: Digi-Key; Supplier Part Number 1: 311-54.9KHRDKR-ND; Tolerance: ±1%; Library Name: SOARSchematics.SchLib
R15 = ERJ-3EKF2211V: RES SMD 2.21K OHM 1% 1/10W 0603; Manufacturer: Panasonic Electronic Components; Manufacturer Part Number: ERJ-3GEYJ103V; Power  (W): 0.1W; Temperature Coefficient: ±100ppm/°C; Component Kind: Standard; ComponentLink1Description: Datasheet; ComponentLink1URL: https://industrial.panasonic.com/cdbs/www-data/pdf/RDA0000/AOA0000C304.pdf; Composition: Thick Film; Operating Temperature: -55°C ~ 155°C; Package: 0603 (1608 Metric); Supplier 1: Digi-Key; Supplier Part Number 1: P10KGCT-ND; Tolerance: ±1%; Library Name: SOARSchematics.SchLib
U1 = SC4525ESETRT: IC REG BUCK ADJUSTABLE 3A 8SOIC; Manufacturer: Semtech Corporation; Component Kind: Standard; ComponentLink1Description: Datasheet; ComponentLink1URL: https://semtech.my.salesforce.com/sfc/p/#E0000000JelG/a/2R0000001Nr2/6kOzpjdk.AJmLpgrGiFAWW58LpG5sa.kG4035Q65fV4; Manufacturer Part Number: SC4525ESETRT; Library Name: SOARSchematics.SchLib
U2 = TPS22810DBVR: IC PWR SWITCH N-CHAN 1:1 SOT23-6; Manufacturer: Texas Instruments; Component Kind: Standard; ComponentLink1Description: Datasheet; ComponentLink1URL: http://www.ti.com/lit/ds/symlink/tps22810.pdf; Manufacturer Part Number: TPS22810DBVR; Library Name: SOARSchematics.SchLib
U3 = TPS562208DDCT: IC REG BUCK ADJ 2A TSOT23-6; Manufacturer: Texas Instruments; Frequency - Switching: 580kHz; Function: Step-Down; Number of Outputs: 1; Output Configuration: Positive; Output Type: Adjustable; Synchronous Rectifier: Yes; Voltage - Input (Max): 17V; Voltage - Input (Min): 4.5V; Voltage - Output (Min/Fixed): 0.768V; Component Kind: Standard; ComponentLink1Description: Datasheet; ComponentLink1URL: http://www.ti.com/general/docs/suppproductinfo.tsp?distId=10&gotoUrl=http%3A%2F%2Fwww.ti.com%2Flit%2Fgpn%2Ftps562208; Current - Output: 2A; Mounting Type: Surface Mount; Operating Temperature: -40°C ~ 125°C (TJ); Packaging: Cut Tape (CT); Series: D-CAP2™, Eco-Mode™; Supplier 1: Digi-Key; Supplier Part Number 1: 296-43806-1-ND; Topology: Buck; Voltage - Output (Max): 17V; Library Name: SOARSchematics.SchLib
U4 = LP3878MRX-ADJ/NOPB: IC REG LIN POS ADJ 800MA 8SO; Manufacturer: Texas Instruments; Control Features: Enable; Current - Quiescent (Iq): 1.5mA; Current - Supply (Max): 15mA; Number of Regulators: 1; Protection Features: Over Current, Over Temperature, Short Circuit; PSRR: 60dB (1kHz); Voltage - Input (Max): 16V; Voltage - Output (Max): 5.5V; Voltage - Output (Min/Fixed): 1V; Voltage Dropout (Max): 1.1V @ 800mA; Base Part Number: LP3878; Component Kind: Standard; ComponentLink1Description: Datasheet; ComponentLink1URL: http://www.ti.com/lit/ds/symlink/lp3878-adj.pdf; Current - Output: 800mA; Manufacturer Part Number: LP3878MRX-ADJ/NOPB; Mounting Type: Surface Mount; Operating Temperature: -40°C ~ 125°C; Package / Case: 8-PowerSOIC (0.154", 3.90mm Width); Supplier 1: Digi-Key; Supplier Part Number 1: LP3878MRX-ADJ/NOPBTR-ND; Library Name: SOARSchematics.SchLib
